# Altium schematic sheet 03_Mos.SchDoc
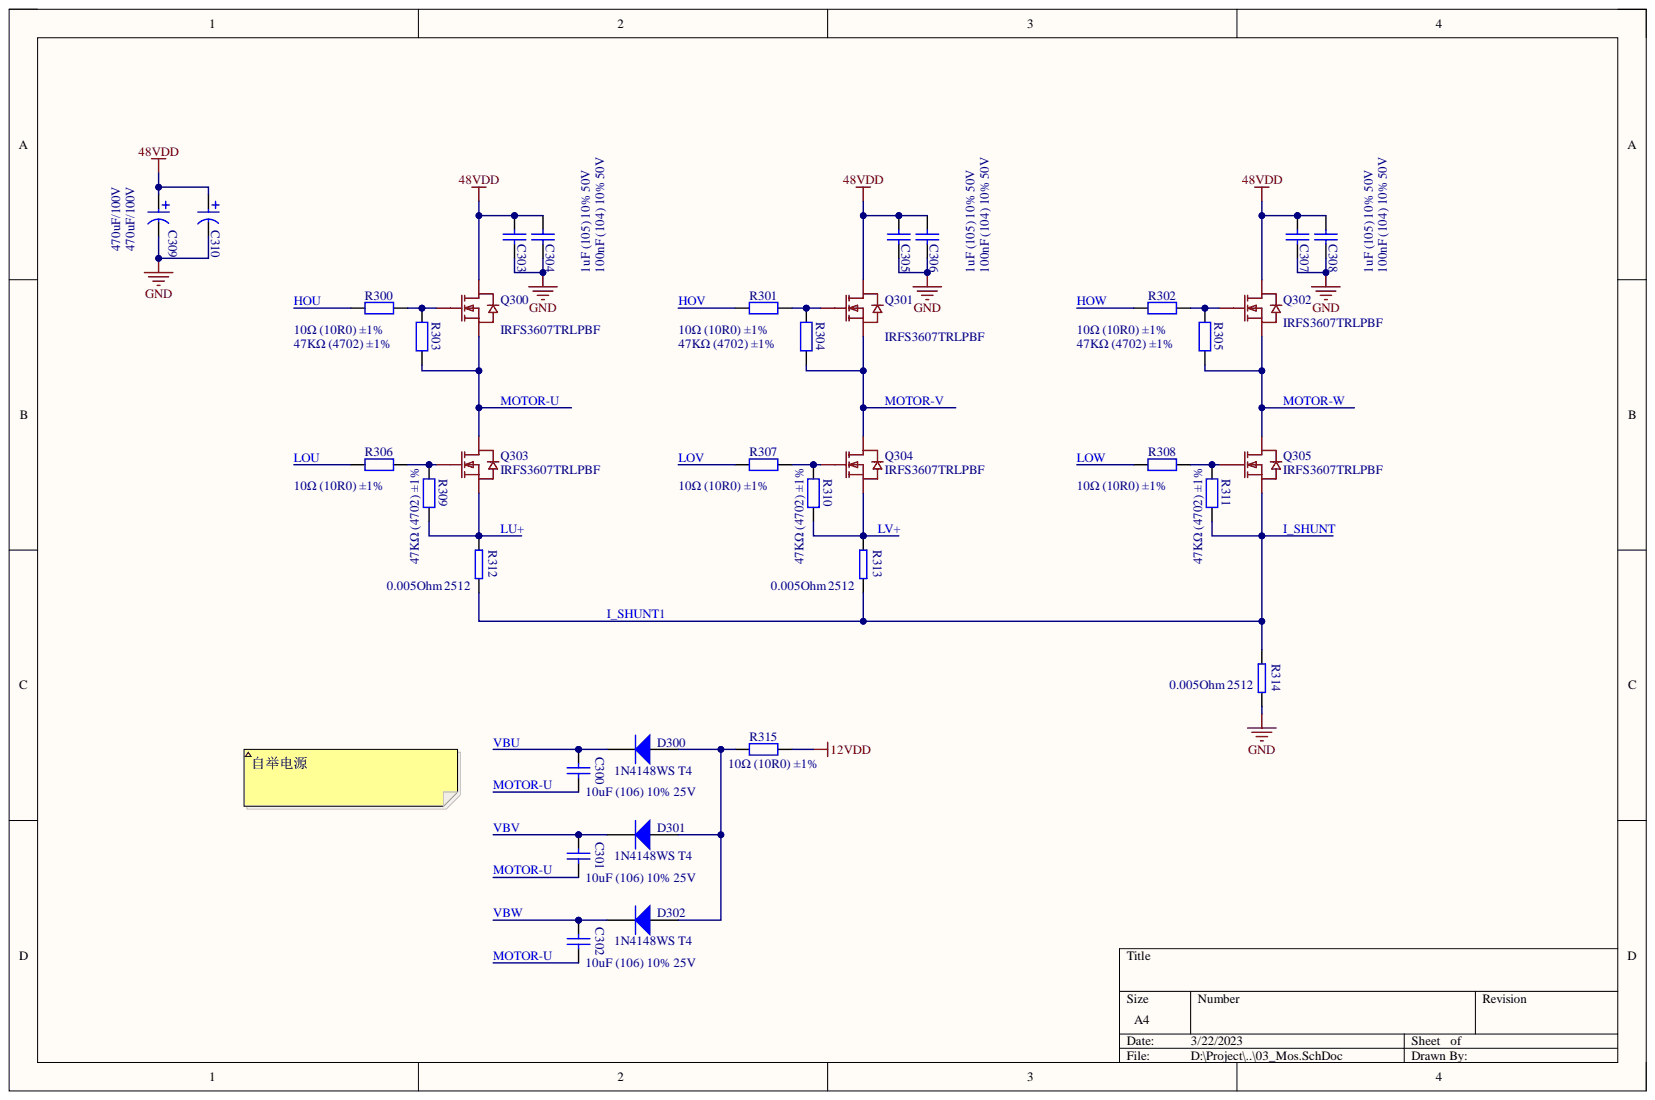
[diagram p0: 03_Mos.SchDoc]

## Parts
C300  10uF (106) 10% 25V  [0805_C]
C301  10uF (106) 10% 25V  [0805_C]
C302  10uF (106) 10% 25V  [0805_C]
C303  100nF (104) 10% 50V  [1206_C]
C304  1uF (105) 10% 50V  [1206_C]
C305  100nF (104) 10% 50V  [1206_C]
C306  1uF (105) 10% 50V  [1206_C]
C307  100nF (104) 10% 50V  [1206_C]
C308  1uF (105) 10% 50V  [1206_C]
C309  470uF/100V  [CAP_D16_L31.5]
C310  470uF/100V  [CAP_D16_L31.5]
D300  1N4148WS T4  [SOD-323]
D301  1N4148WS T4  [SOD-323]
D302  1N4148WS T4  [SOD-323]
Q300  IRFS3607TRLPBF  [IRFB4127]
Q301  IRFS3607TRLPBF  [IRFB4127]
Q302  IRFS3607TRLPBF  [IRFB4127]
Q303  IRFS3607TRLPBF  [IRFB4127]
Q304  IRFS3607TRLPBF  [IRFB4127]
Q305  IRFS3607TRLPBF  [IRFB4127]
R300  10Ω (10R0) ±1%  [0805_R]
R301  10Ω (10R0) ±1%  [0805_R]
R302  10Ω (10R0) ±1%  [0805_R]
R303  47KΩ (4702) ±1%  [0805_R]
R304  47KΩ (4702) ±1%  [0805_R]
R305  47KΩ (4702) ±1%  [0805_R]
R306  10Ω (10R0) ±1%  [0805_R]
R307  10Ω (10R0) ±1%  [0805_R]
R308  10Ω (10R0) ±1%  [0805_R]
R309  47KΩ (4702) ±1%  [0805_R]
R310  47KΩ (4702) ±1%  [0805_R]
R311  47KΩ (4702) ±1%  [0805_R]
R312  0.005Ohm 2512  [R2512]
R313  0.005Ohm 2512  [R2512]
R314  0.005Ohm 2512  [R2512]
R315  10Ω (10R0) ±1%  [0805_R]

## Nets
12VDD: R315.2
48VDD: C303.2 C304.2 C305.2 C306.2 C307.2 C308.2 C309.1 C310.1 Q300.2 Q301.2 Q302.2
GND: C303.1 C304.1 C305.1 C306.1 C307.1 C308.1 C309.2 C310.2 R314.1
HOU: R300.1
HOV: R301.1
HOW: R302.1
I_SHUNT: Q305.3 R311.1 R312.1 R313.1 R314.2
LOU: R306.1
LOV: R307.1
LOW: R308.1
LU+: Q303.3 R309.1 R312.2
LV+: Q304.3 R310.1 R313.2
MOTOR-U: C300.1 C301.1 C302.1 Q300.3 Q303.2 R303.1
MOTOR-V: Q301.3 Q304.2 R304.1
MOTOR-W: Q302.3 Q305.2 R305.1
VBU: C300.2 D300.2
VBV: C301.2 D301.2
VBW: C302.2 D302.2
NetD300_1: D300.1 D301.1 D302.1 R315.1
NetQ300_1: Q300.1 R300.2 R303.2
NetQ301_1: Q301.1 R301.2 R304.2
NetQ302_1: Q302.1 R302.2 R305.2
NetQ303_1: Q303.1 R306.2 R309.2
NetQ304_1: Q304.1 R307.2 R310.2
NetQ305_1: Q305.1 R308.2 R311.2

## Library data of the parts
C300-C302 = 0805 10uF (106) 10% 25V: 贴片电容; Component Kind: Standard; ComponentLink1Description: 供应商链接; ComponentLink1URL: http://www.szlcsc.com/product/details_16532.html; Library Name: Miscellaneous Devices LC.IntLib; Package: 0805; Supplier: LC; SuppliersPartNumber: C15850
C303 C305 C307 = 1206 100nF (104) 10% 50V: 贴片电容; Component Kind: Standard; ComponentLink1Description: 供应商链接; ComponentLink1URL: http://www.szlcsc.com/product/details_25237.html; Library Name: Miscellaneous Devices LC.IntLib; Package: 1206; Supplier: LC; SuppliersPartNumber: C24497
C304 C306 C308 = 1206 1uF (105) 10% 50V: 贴片电容; Component Kind: Standard; ComponentLink1Description: 供应商链接; ComponentLink1URL: http://www.szlcsc.com/product/details_2200.html; Library Name: Miscellaneous Devices LC.IntLib; Package: 1206; Supplier: LC; SuppliersPartNumber: C1848
C309 C310 = CAP2: 电解电容; Component Kind: Standard; Library Name: Schlibv2.SchLib
D300-D302 = SOD-323 1N4148WS T4: 二极管; Component Kind: Standard; ComponentLink1Description: 供应商链接; ComponentLink1URL: http://www.szlcsc.com/product/details_2485.html; Library Name: Miscellaneous Devices LC.IntLib; Package: SOD-323; Supplier: LC; SuppliersPartNumber: C2128
Q300-Q305 = IRFB4127PBF: Component Kind: Standard; Library Name: RayPCB20210502.SchLib
R300-R302 R306-R308 R315 = 0805 10Ω (10R0) 1%: 贴片电阻; Component Kind: Standard; ComponentLink1Description: 供应商链接; ComponentLink1URL: http://www.szlcsc.com/product/details_18103.html; Library Name: Miscellaneous Devices LC.IntLib; Package: 0805; Supplier: LC; SuppliersPartNumber: C17415
R303-R305 R309-R311 = 0805 47KΩ (4702) 1%: 贴片电阻; Component Kind: Standard; ComponentLink1Description: 供应商链接; ComponentLink1URL: http://www.szlcsc.com/product/details_18401.html; Library Name: Miscellaneous Devices LC.IntLib; Package: 0805; Supplier: LC; SuppliersPartNumber: C17713
R312-R314 = Res10K: Component Kind: Standard; Library Name: RayPCB20210502.SchLib
